# Altium schematic sheet Inkplate6+_main.SchDoc(Inkplate6+_main)
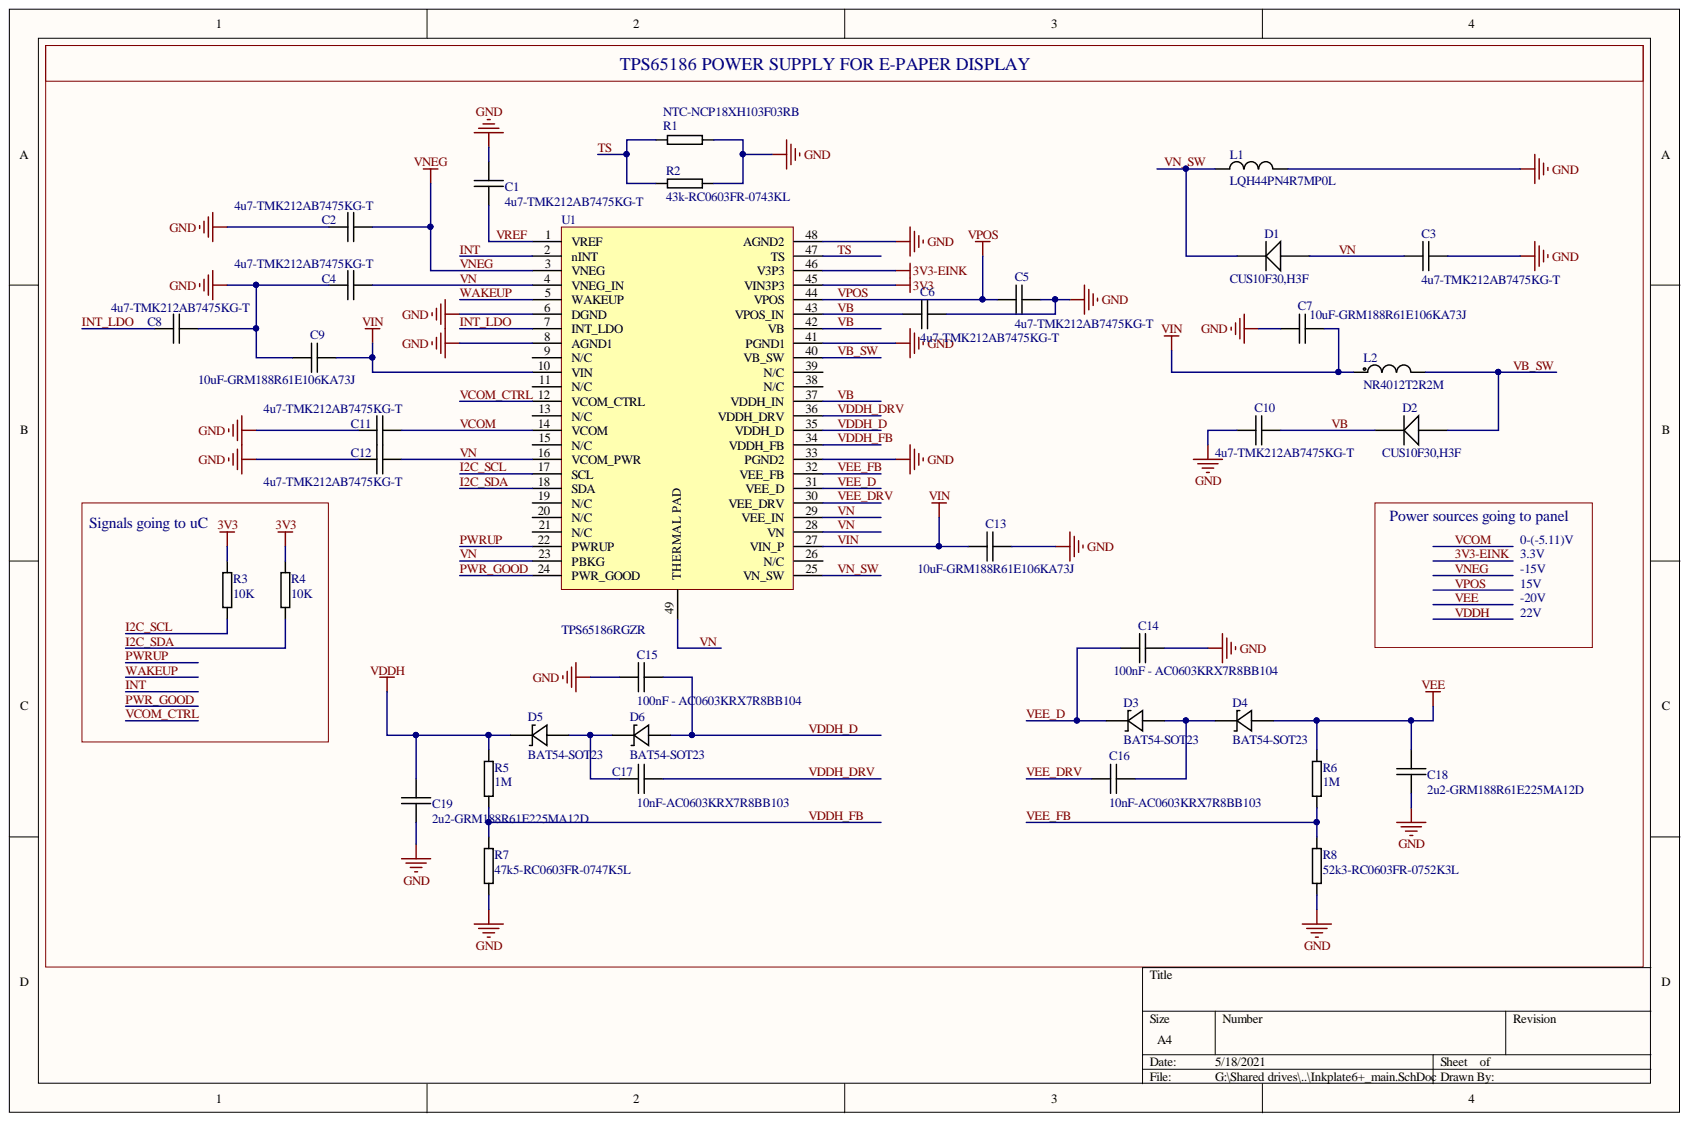
[diagram p0: Inkplate6+_main.SchDoc]

## Parts
C1  4u7-TMK212AB7475KG-T  [0805C]
C2  4u7-TMK212AB7475KG-T  [0805C]
C3  4u7-TMK212AB7475KG-T  [0805C]
C4  4u7-TMK212AB7475KG-T  [0805C]
C5  4u7-TMK212AB7475KG-T  [0805C]
C6  4u7-TMK212AB7475KG-T  [0805C]
C7  10uF-GRM188R61E106KA73J  [0603C]
C8  4u7-TMK212AB7475KG-T  [0805C]
C9  10uF-GRM188R61E106KA73J  [0603C]
C10  4u7-TMK212AB7475KG-T  [0805C]
C11  4u7-TMK212AB7475KG-T  [0805C]
C12  4u7-TMK212AB7475KG-T  [0805C]
C13  10uF-GRM188R61E106KA73J  [0603C]
C14  100nF - AC0603KRX7R8BB104  [0603C]
C15  100nF - AC0603KRX7R8BB104  [0603C]
C16  10nF-AC0603KRX7R8BB103  [0603C]
C17  10nF-AC0603KRX7R8BB103  [0603C]
C18  2u2-GRM188R61E225MA12D  [0603C]
C19  2u2-GRM188R61E225MA12D  [0603C]
D1  CUS10F30,H3F  [SOD-323]
D2  CUS10F30,H3F  [SOD-323]
D3  BAT54-SOT23  [SOT-23-3]
D4  BAT54-SOT23  [SOT-23-3]
D5  BAT54-SOT23  [SOT-23-3]
D6  BAT54-SOT23  [SOT-23-3]
L1  LQH44PN4R7MP0L  [LQH44PN4R7MP0_Inductor]
L2  NR4012T2R2M  [NR4012T2R2M_Fixed Inductor]
R1  NTC-NCP18XH103F03RB  [0603R]
R2  43k-RC0603FR-0743KL  [0603R]
R3  10K  [0603R]
R4  10K  [0603R]
R5  1M  [0603R]
R6  1M  [0603R]
R7  47k5-RC0603FR-0747K5L  [0603R]
R8  52k3-RC0603FR-0752K3L  [0603R]
U1  TPS65186RGZR  [TPS65186_QFN_48]

## Nets
3V3: R3.2 R4.2 U1.45(VIN3P3)
GND: C1.2 C2.1 C3.1 C4.1 C5.1 C6.1 C7.1 C8.2 C9.1 C10.2 C11.1 C12.1 C13.2 C14.2 C15.1 C18.1 C19.1 L1.2 R1.2 R2.2 R7.1 R8.1 U1.6(DGND) U1.8(AGND1) U1.33(PGND2) U1.41(PGND1) U1.48(AGND2)
3V3-EINK: U1.46(V3P3)
I2C_SCL: R3.1 U1.17(SCL)
I2C_SDA: R4.1 U1.18(SDA)
INT: U1.2(nINT)
INT_LDO: C8.1 U1.7(INT_LDO)
PWR_GOOD: U1.24(PWR_GOOD)
PWRUP: U1.22(PWRUP)
TS: R1.1 R2.1 U1.47(TS)
VB: C6.2 C10.1 D2.2 U1.37(VDDH_IN) U1.42(VB) U1.43(VPOS_IN)
VB_SW: D2.1 L2.2 U1.40(VB_SW)
VCOM: C11.2 U1.14(VCOM)
VCOM_CTRL: U1.12(VCOM_CTRL)
VDDH: C19.2 D5.3 R5.2
VDDH_D: C15.2 D6.1 U1.35(VDDH_D)
VDDH_DRV: C17.2 U1.36(VDDH_DRV)
VDDH_FB: R5.1 R7.2 U1.34(VDDH_FB)
VEE: C18.2 D4.1 R6.2
VEE_D: C14.1 D3.3 U1.31(VEE_D)
VEE_DRV: C16.1 U1.30(VEE_DRV)
VEE_FB: R6.1 R8.2 U1.32(VEE_FB)
VIN: C7.2 C9.2 C13.1 L2.1 U1.10(VIN) U1.27(VIN_P)
VN: C3.2 C4.2 C12.2 D1.1 U1.4(VNEG_IN) U1.16(VCOM_PWR) U1.23(PBKG) U1.28(VN) U1.29(VEE_IN) U1.49(THERMAL_PAD)
VN_SW: D1.2 L1.1 U1.25(VN_SW)
VNEG: C2.2 U1.3(VNEG)
VPOS: C5.2 U1.44(VPOS)
VREF: C1.1 U1.1(VREF)
WAKEUP: U1.5(WAKEUP)
NetC16_2: C16.2 D3.1 D4.3
NetC17_1: C17.1 D5.1 D6.3

Not connected: U1 9(N/C) 11(N/C) 13(N/C) 15(N/C) 19(N/C) 20(N/C) 21(N/C) 26(N/C) 38(N/C) 39(N/C)

## Library data of the parts
C1-C6 C8 C10-C12 = 0805C: Component Kind: Standard; Library Name: e-radionica.com schematics.SchLib
C7 C9 C13-C19 = 0603C: Component Kind: Standard; Library Name: e-radionica.com schematics.SchLib
D1 D2 = DIODA SOD-323: Supplier Part Number 3: BAR6303WE6327HTSA1CT-ND; Supplier Part Number 2: 726-BAR6303WE6327HTS; Supplier Part Number 1: BAR6303WE6327HTSA1; Supplier 3: Digi-Key; Supplier 2: Mouser; Supplier 1: Arrow; Component Kind: Standard; Library Name: e-radionica.com schematics.SchLib
D3-D6 = BAT54-SOT23: SINGLE SHOTTKY DIODE; Manufacturer Part Number: BAT54,215; Manufacturer: NXP Semiconductors; Voltage - Forward (Vf) (Max) @ If: 800mV @ 100mA; Voltage - DC Reverse (Vr) (Max): 30V; Speed: Small Signal =< 200mA (Io), Any Speed; Reverse Recovery Time (trr): 5ns; Diode Type: Schottky; Current - Reverse Leakage @ Vr: 2µA @ 25V; Current - Average Rectified (Io): 200mA (DC); Capacitance @ Vr, F: 10pF @ 1V, 1MHz; Supplier Part Number 1: 568-1610-1-ND; Supplier Part Number: 568-1610-1-ND; Supplier 1: Digi-Key; Supplier: Digi-Key; RoHS: RoHS Compliant; Packaging: Cut Tape (CT); Package / Case: SOT-23-3, TO-236-3, Micro3™, SSD3, SST3; Mounting Type: Surface Mount; Component Kind: Standard; Category: Discrete Semiconductor Products; Library Name: e-radionica.com schematics.SchLib
L1 = LQH44PN4R7MP0L: Inductor Power Chip Shielded Wirewound 4.7uH 20% 1MHz Ferrite 1.7A 96mOhm DCR 1515 Embossed T/R; Manufacturer: Murata; Series Resistance: 80mR; Self Resonant Frequency: 40MHz; DC Resistance (DCR): 96mR; Width: 0.157inch; Weight: 0.003527oz; Tolerance: 20%; Test Frequency: 1MHz; Termination: SMD/SMT; Supplier Part Number 1: 490-5328-1-ND; Supplier 1: Digi-Key; Stock 1: 18102; Shielding: Shielded; Saturation Current: 1.7A; REACH SVHC: No SVHC; Radiation Hardening: No; Pricing 1: 1=0.43, 10=0.379, 100=0.2708, 500=0.2275 (USD); Packaging: Tape and Reel; Package Quantity: 1; Mount: Surface Mount; Min Operating Temperature: -40°C; Military Standard: Not; Max Operating Temperature: 85°C; Max DC Current: 1.7A; Manufacturer Part Number: LQH44PN4R7MP0L; Length: 4mm; Lead Free: Lead Free; Inductance Tolerance: 20%; Inductance: 4.7uH; Height - Seated (Max): 0.071inch; Height: 0.9mm; Depth: 4.2mm; DC Current: 1.7A; Current Rating: 1.7A; Core Material: Ferrite; Composition: Wirewound; ComponentLink7URL: http://www.farnell.com/datasheets/490895.pdf?src-supplier=Element14; ComponentLink7Description: http://www.farnell.com/datasheets/490895.pdf?src-supplier=Element14; ComponentLink6URL: http://search.murata.co.jp/Ceramy/image/img/PDF/ENG/L0075S0103LQH44P_P0.pdf?src-supplier=Avnet+Europe; ComponentLink6Description: http://search.murata.co.jp/Ceramy/image/img/PDF/ENG/L0075S0103LQH44P_P0.pdf?src-supplier=Avnet+Europe; ComponentLink5URL: https://www.distrelec.biz/Web/Downloads/g_/ds/lqh44pn_eng_ds.pdf?mime=application%2Fpdf&src-supplier=Distrelec; ComponentLink5Description: https://www.distrelec.biz/Web/Downloads/g_/ds/lqh44pn_eng_ds.pdf?mime=application%2Fpdf&src-supplier=Distrelec; ComponentLink4URL: http://www.mouser.com/ds/2/281/o05e-522697.pdf?src-supplier=Mouser; ComponentLink4Description: http://www.mouser.com/ds/2/281/o05e-522697.pdf?src-supplier=Mouser; ComponentLink3URL: http://psearch.murata.com/inductor/product/LQH44PN4R7MP0%23.pdf?src-supplier=Future+Electronics; ComponentLink3Description: http://psearch.murata.com/inductor/product/LQH44PN4R7MP0%23.pdf?src-supplier=Future+Electronics; ComponentLink2URL: http://search.murata.co.jp/Ceramy/image/img/PDF/ENG/L0075S0103LQH44P_P0.pdf?src-supplier=Avnet; ComponentLink2Description: http://search.murata.co.jp/Ceramy/image/img/PDF/ENG/L0075S0103LQH44P_P0.pdf?src-supplier=Avnet; ComponentLink1URL: http://components.arrow.com/part/dataview/48185372S8976820N2606?src-supplier=Arrow; ComponentLink1Description: http://components.arrow.com/part/dataview/48185372S8976820N2606?src-supplier=Arrow; Component Kind: Standard; Category: Fixed Inductors; Case Code (Metric): 4040; Case Code (Imperial): 1515; Case/Package: 1515; Library Name: e-radionica.com schematics.SchLib
L2 = NR4012T2R2M: FIXED IND 2.2UH 1.2A 108 MOHM; Manufacturer: Taiyo Yuden; Tolerance: 20%; Test Frequency: 100kHz; Shielding: Shielded; Self Resonant Frequency: 66MHz; Saturation Current: 1.65A; DC Resistance (DCR): 90mR; Core Material: Ferrite; Width: 4mm; Termination: SMD/SMT; Supplier Part Number 1: 587-1661-6-ND; Supplier 1: Digi-Key; Stock 1: 1790; RMS Current (Irms): 1.2A; REACH SVHC: Unknown; Pricing 1: 1=0.44, 10=0.382, 100=0.2731, 500=0.22942, 1000=0.18573 (USD); Packaging: Cut Tape; Package Quantity: 4500; Mount: Surface Mount; Min Operating Temperature: -25°C; Max Operating Temperature: 120°C; Max DC Current: 1.65A; Manufacturer Part Number: NR4012T2R2M; Length: 0.157inch; Lead Free: Lead Free; Inductance Tolerance: 20%; Inductance: 2.2uH; Height - Seated (Max): 0.047inch; Height: 1.2mm; Halogen Free: Halogen Free; Current Rating: 1.2A; Composition: Wirewound; ComponentLink2URL: http://www.yuden.co.jp/productdata/catalog/en/wound04_e.pdf?src-supplier=Digi-Key; ComponentLink2Description: http://www.yuden.co.jp/productdata/catalog/en/wound04_e.pdf?src-supplier=Digi-Key; ComponentLink1URL: http://www.yuden.co.jp/productdata/catalog/en/wound04_e.pdf?src-supplier=Avnet; ComponentLink1Description: http://www.yuden.co.jp/productdata/catalog/en/wound04_e.pdf?src-supplier=Avnet; Component Kind: Standard; Category: Fixed Inductors; Case/Package: Nonstandard; Library Name: e-radionica.com schematics.SchLib
R1-R8 = 0603R: Component Kind: Standard; Library Name: e-radionica.com schematics.SchLib
U1 = TPS65186RGZR: IC PWR MGMT E INK 48VQFN; Manufacturer: Texas Instruments; Quiescent Current: 5.5mA; Power Dissipation: 2W; Output Current: 120mA; Operating Supply Voltage: 6V; Min Input Voltage: 3V; Type: Integrated Circuit (IC); Supplier Part Number 1: 296-29566-1-ND; Supplier 1: Digi-Key; Stock 1: 2619; Pricing 1: 1=4.86, 10=4.368, 100=3.5791, 500=3.04686, 1000=2.56964 (USD); Packaging: Tape and Reel; Package Quantity: 2500; Operating Supply Current: 5.5mA; Number of Pins: 48; Min Operating Temperature: -40°C; Max Operating Temperature: 85°C; Max Input Voltage: 6V; Manufacturer Part Number: TPS65186RGZR; Length: 7mm; Lead Free: Lead Free; Input Current: 5.5mA; Height: 0.9mm; ComponentLink2URL: http://www.ti.com/lit/ds/symlink/tps65186.pdf?src-supplier=Digi-Key; ComponentLink2Description: http://www.ti.com/lit/ds/symlink/tps65186.pdf?src-supplier=Digi-Key; ComponentLink1URL: http://www.ti.com/general/docs/lit/getliterature.tsp?genericPartNumber=TPS65186&&fileType=pdf&src-supplier=Avnet; ComponentLink1Description: http://www.ti.com/general/docs/lit/getliterature.tsp?genericPartNumber=TPS65186&&fileType=pdf&src-supplier=Avnet; Component Kind: Standard; Category: PMIC - Power Management; Case/Package: VQFN; Library Name: e-radionica.com schematics.SchLib
